# Altium schematic sheet GSMRelay.SchDoc(GSMRelay)
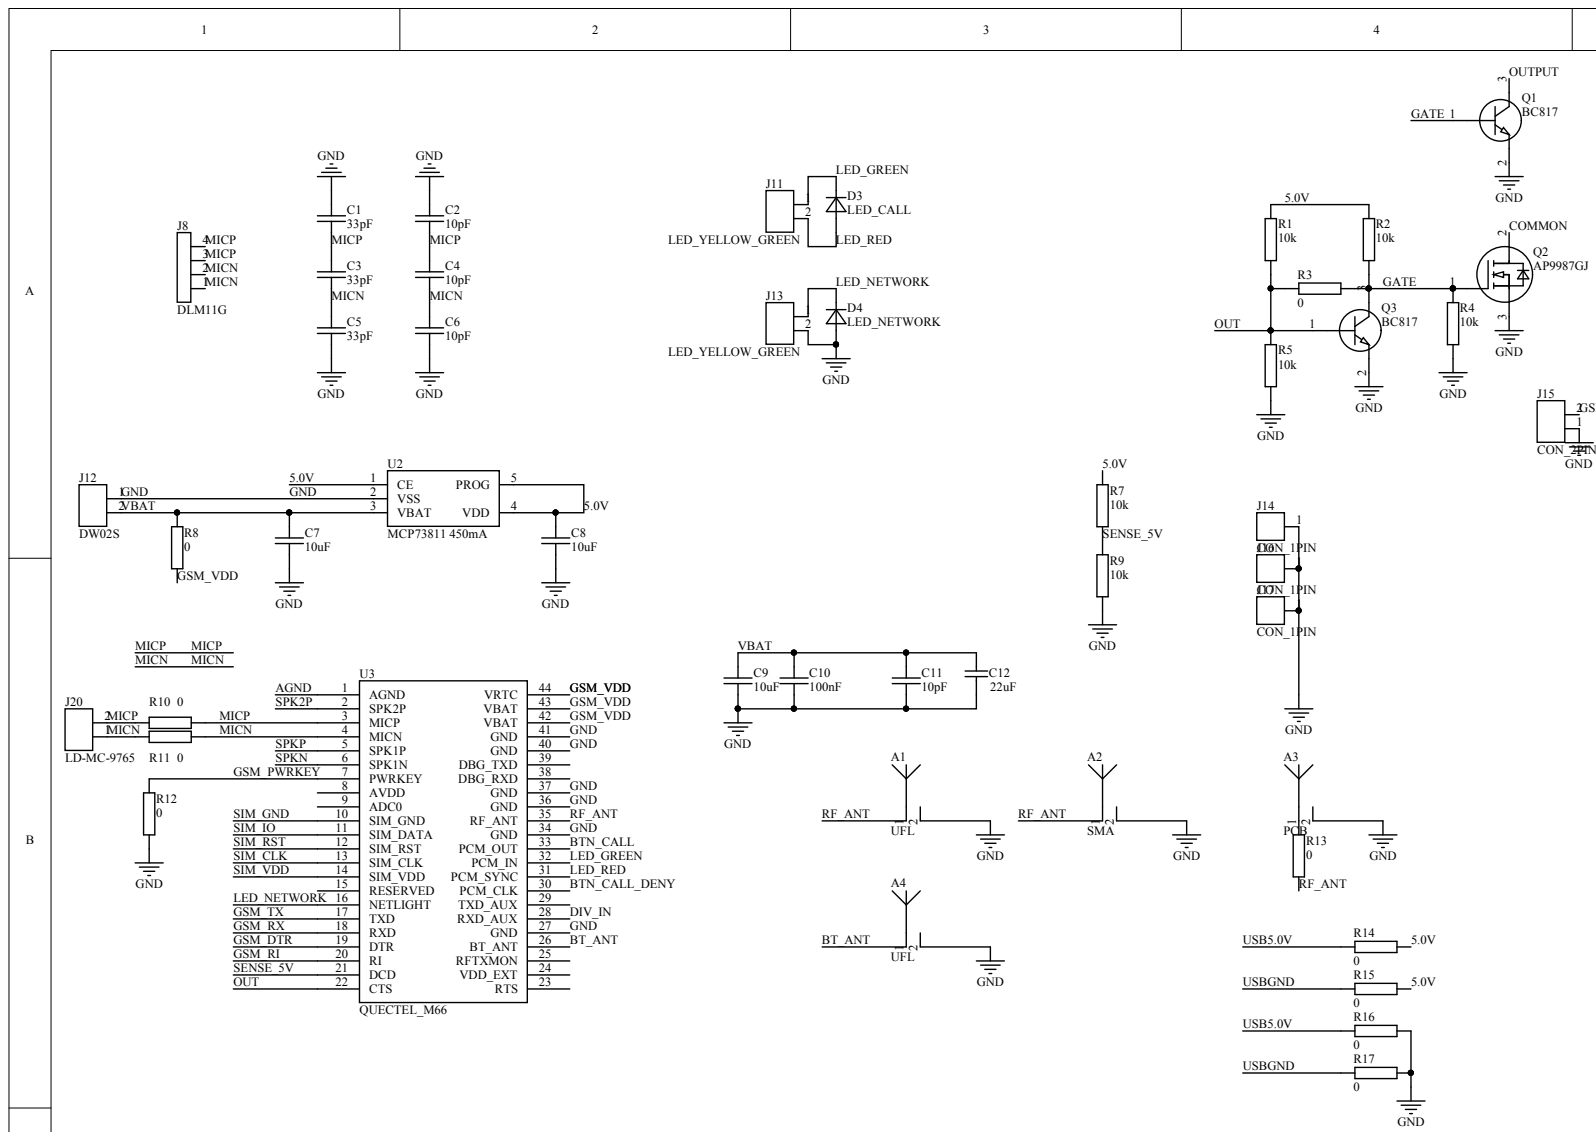
[diagram p0: GSMRelay.SchDoc, top left]
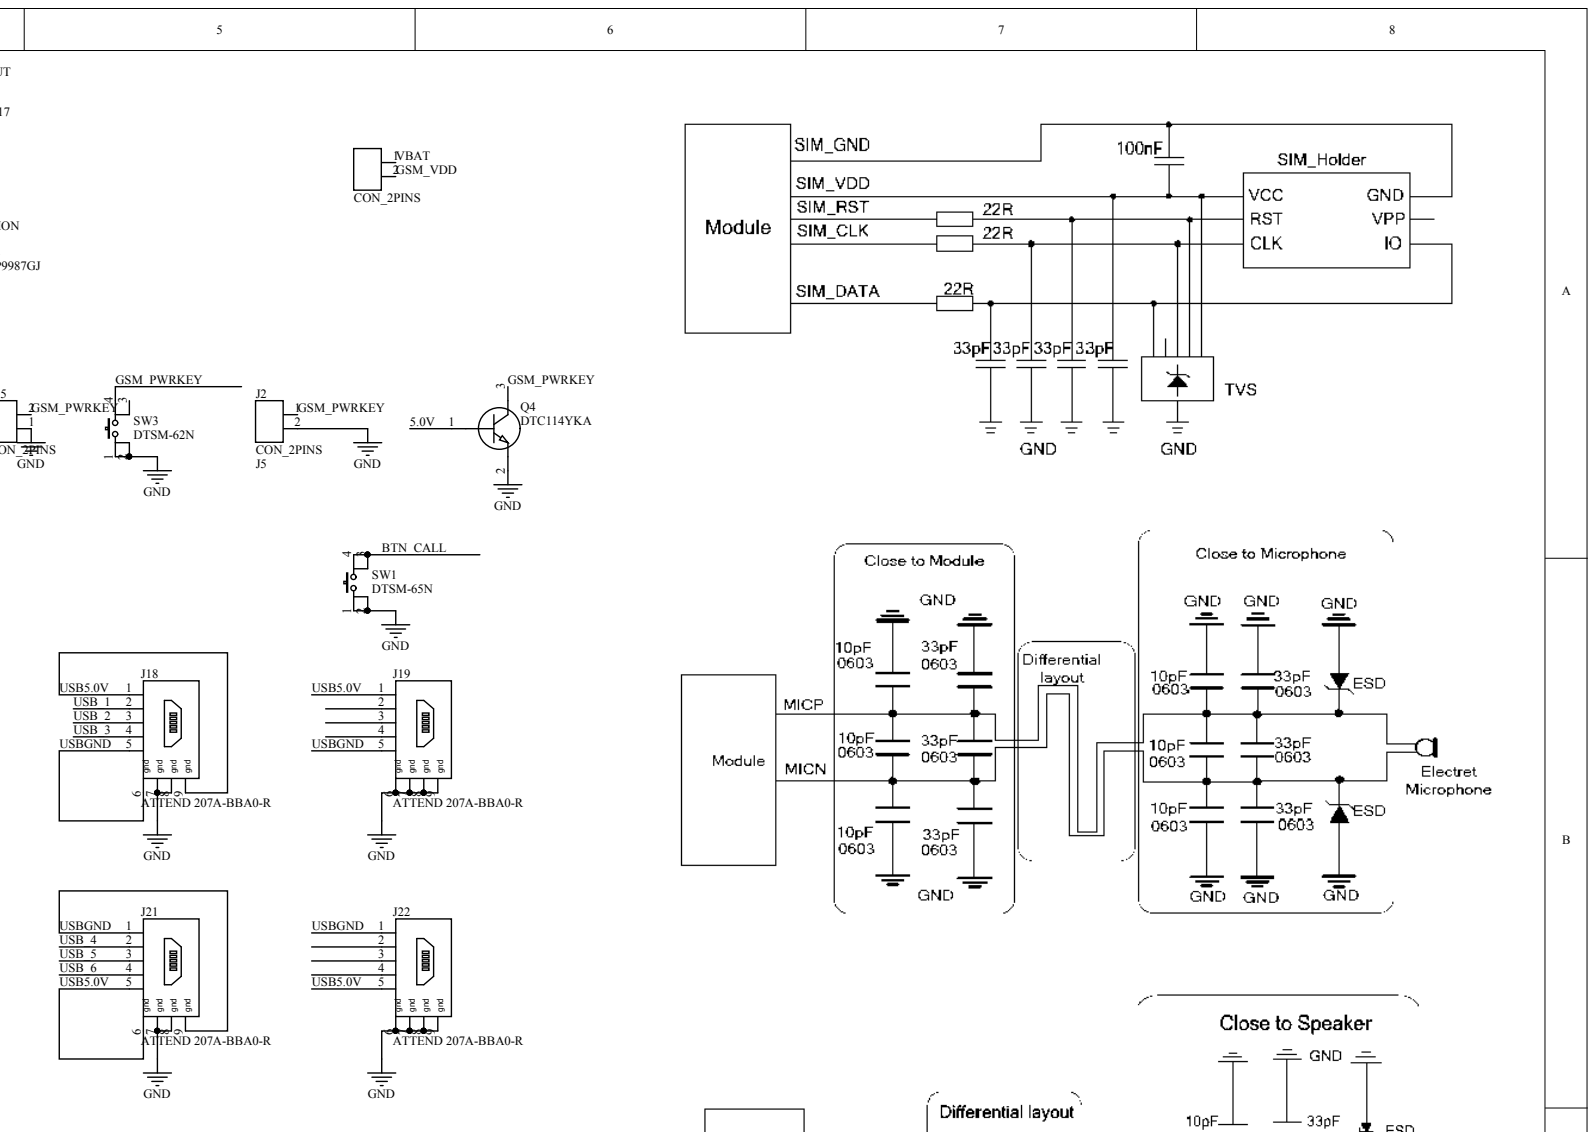
[diagram p1: GSMRelay.SchDoc, top right]
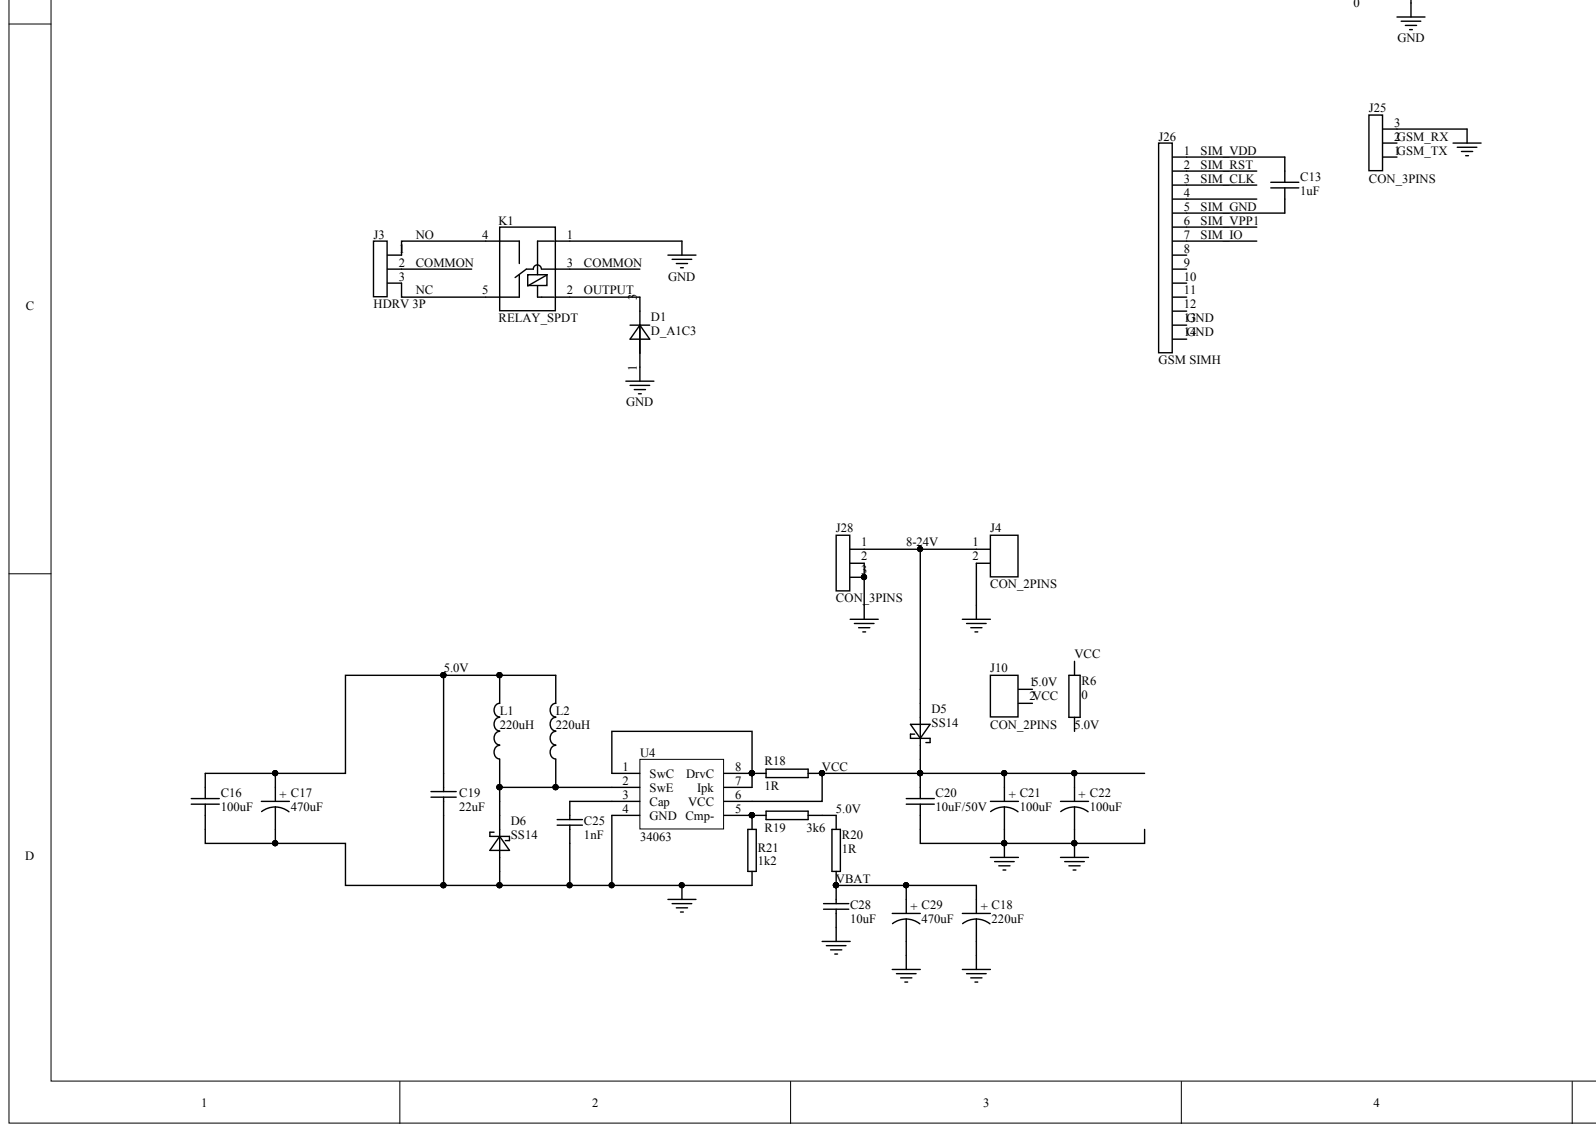
[diagram p2: GSMRelay.SchDoc, bottom left]
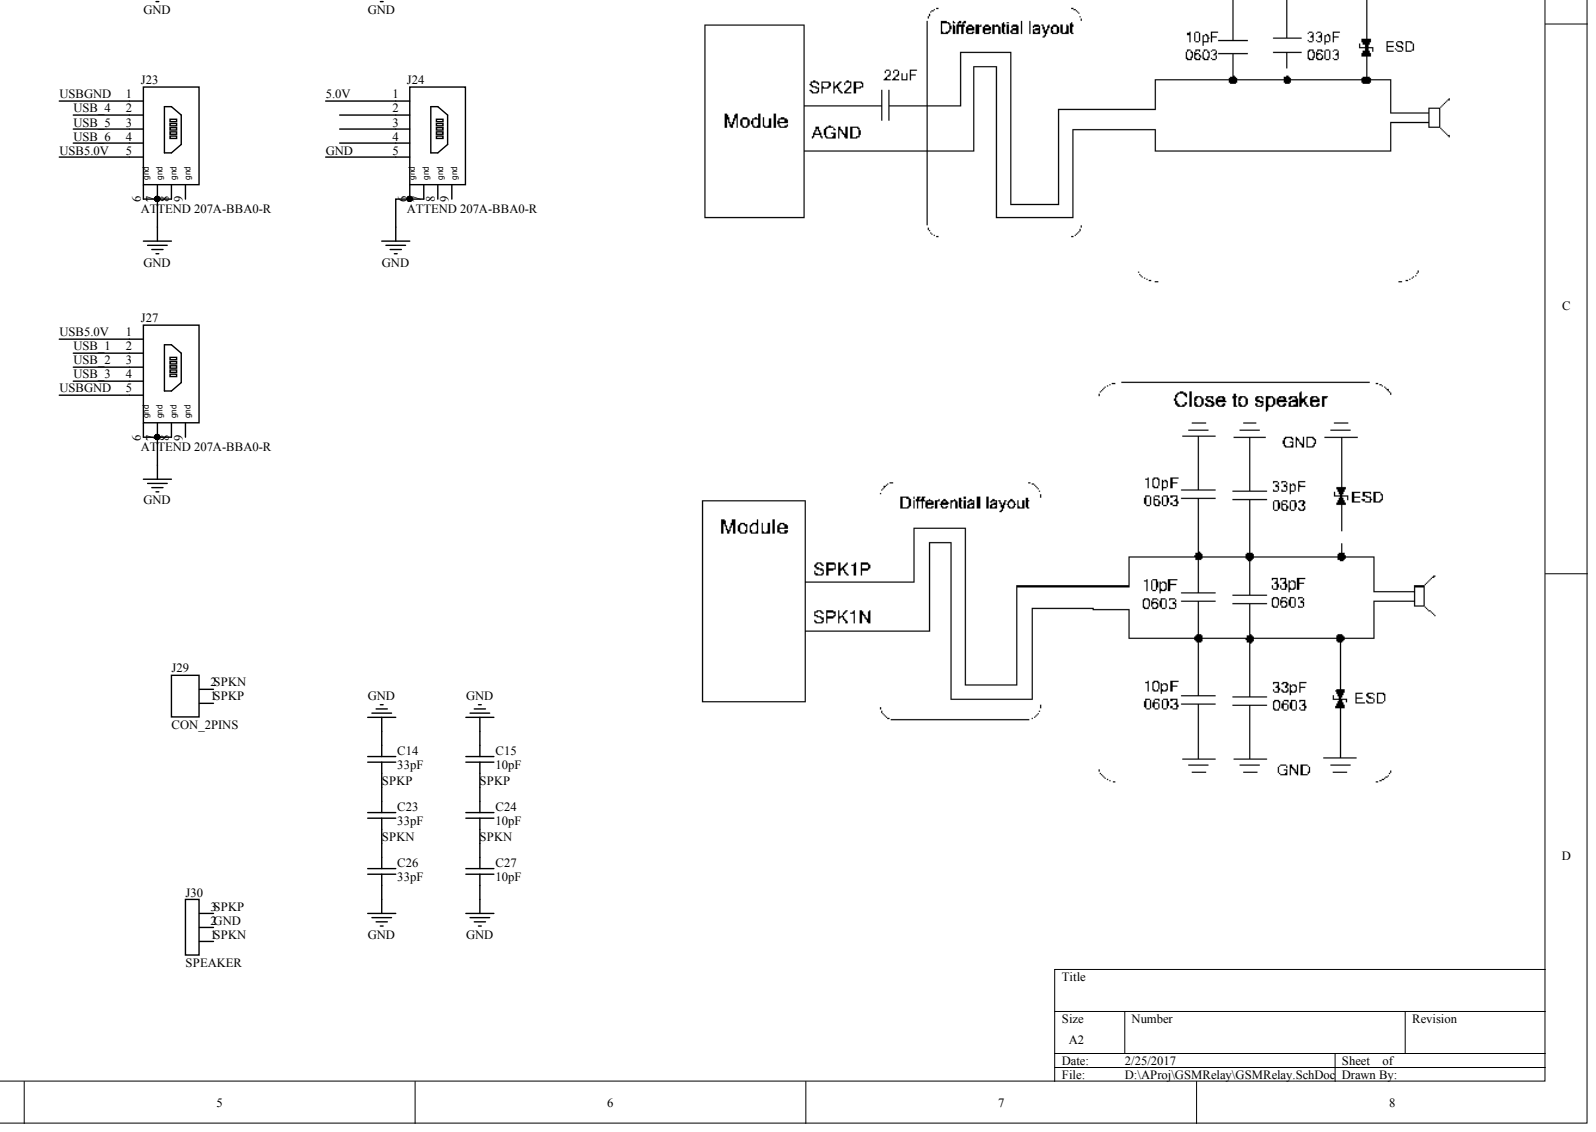
[diagram p3: GSMRelay.SchDoc, bottom right]

## Parts
A1  UFL  [SMD_CON_ANTENNA_UFL]
A2  SMA  [SMD_CON_ANTENNA_SMA_RIGHT_ANGLE]
A3  PCB  [SMD_CON_ANTENNA_GSM_PCB_1]
A4  UFL  [SMD_CON_ANTENNA_UFL]
C1  33pF  [SMD_0603_CAPC1608X95N]
C2  10pF  [SMD_0603_CAPC1608X95N]
C3  33pF  [SMD_0603_CAPC1608X95N]
C4  10pF  [SMD_0603_CAPC1608X95N]
C5  33pF  [SMD_0603_CAPC1608X95N]
C6  10pF  [SMD_0603_CAPC1608X95N]
C7  10uF  [SMD_0603_CAPC1608X95N]
C8  10uF  [SMD_0603_CAPC1608X95N]
C9  10uF  [SMD_0805_CAPC2012X95N]
C10  100nF  [SMD_0603_CAPC1608X95N]
C11  10pF  [SMD_0603_CAPC1608X95N]
C12  22uF  [SMD_1210_CAPC3225X279N]
C13  1uF  [SMD_0603_CAPC1608X95N]
C14  33pF  [SMD_0603_CAPC1608X95N]
C15  10pF  [SMD_0603_CAPC1608X95N]
C16  100uF  [SMD_1210_CAPC3225X279N]
C17  470uF  [PTH_CAPPR250-800X800]
C18  220uF  [SMD_CAPAE660X550N]
C19  22uF  [SMD_0805_CAPC2012X145N]
C20  10uF/50V  [SMD_1210_CAPC3225X279N]
C21  100uF  [SMD_CAPAE660X550N]
C22  100uF  [PTH_CAPPR250-800X800]
C23  33pF  [SMD_0603_CAPC1608X95N]
C24  10pF  [SMD_0603_CAPC1608X95N]
C25  1nF  [SMD_0603_CAPC1608X95N]
C26  33pF  [SMD_0603_CAPC1608X95N]
C27  10pF  [SMD_0603_CAPC1608X95N]
C28  10uF  [SMD_0603_CAPC1608X95N]
C29  470uF  [PTH_CAPPR250-800X800]
D1  D_A1C3  [SMD_SOT23_SOT95P240X120-3N]
D3  LED_CALL  [SMD_0805_LED2012X100N]
D4  LED_NETWORK  [SMD_0805_LED2012X100N]
D5  SS14  [SMD_DO214AC_SMA]
D6  SS14  [SMD_DO214AC_SMA]
J2  CON_2PINS  [PTH_HDRV02W64P254_1X02]
J3  HDRV 3P  [PTH_HDRV03W11060P500_1X03]
J4  CON_2PINS  [PTH_HDRV02W11060P350_1X02]
J5  CON_2PINS  [PTH_HDRV02W64P254_1X02]
J8  DLM11G  [NA]
J10  CON_2PINS  [PTH_HDRV02W64P254_1X02]
J11  LED_YELLOW_GREEN  [PTH_LED-KINGBRIGHT-L-934SGC]
J12  DW02S  [PTH_HDRV02W64P254_1X02_BATTERY]
J13  LED_YELLOW_GREEN  [PTH_LED-KINGBRIGHT-L-934SGC]
J14  CON_1PIN  [PTH_MOUNT_HOLE]
J15  CON_2PINS  [PTH_HDRV02W64P254_1X02]
J16  CON_1PIN  [PTH_MOUNT_HOLE]
J17  CON_1PIN  [PTH_MOUNT_HOLE]
J18  ATTEND 207A-BBA0-R  [SMD_CON_MICRO_USB]
J19  ATTEND 207A-BBA0-R  [SMD_CON-CVILUX-CU04-SCM15B0]
J20  LD-MC-9765  [PTH_MIC_9.6MM_6MM]
J21  ATTEND 207A-BBA0-R  [SMD_CON_MICRO_USB]
J22  ATTEND 207A-BBA0-R  [SMD_CON-CVILUX-CU04-SCM15B0]
J23  ATTEND 207A-BBA0-R  [SMD_CON_MICRO_USB_STRAIGHT]
J24  ATTEND 207A-BBA0-R  [NA]
J25  CON_3PINS  [PTH_HDRV03W64P254_1X03]
J26  GSM SIMH  [SMD_CON-ATTEND_SIM_115B-AAA0-R01]
J27  ATTEND 207A-BBA0-R  [SMD_CON_MICRO_USB_STRAIGHT]
J28  CON_3PINS  [PTH_DC-POWER-JACK_210-112]
J29  CON_2PINS  [PTH_HDRV02W64P254_1X02]
J30  SPEAKER  [PTH_SPEAKER_MCABS-227-RC]
K1  RELAY_SPDT  [PTH_REL_RAS]
L1  220uH  [SMD_INDM600500]
L2  220uH  [PTH_CAPPR250-800X800]
Q1  BC817  [SMD_SOT23_SOT95P240X120-3N]
Q2  AP9987GJ  [PTH_TO220AB]
Q3  BC817  [SMD_SOT23_SOT95P240X120-3N]
Q4  DTC114YKA  [SMD_SOT23_SOT95P240X120-3N]
R1  10k  [SMD_0603_RESC1608X55N]
R2  10k  [SMD_0603_RESC1608X55N]
R3  0  [SMD_0603_RESC1608X55N]
R4  10k  [SMD_0603_RESC1608X55N]
R5  10k  [SMD_0603_RESC1608X55N]
R6  0  [SMD_0603_RESC1608X55N]
R7  10k  [SMD_0603_RESC1608X55N]
R8  0  [SMD_0603_RESC1608X55N]
R9  10k  [SMD_0603_RESC1608X55N]
R10  0  [NA]
R11  0  [NA]
R12  0  [SMD_0603_RESC1608X55N]
R13  0  [SMD_0402_RESC1005X37N]
R14  0  [SMD_0603_RESC1608X55N]
R15  0  [SMD_0603_RESC1608X55N]
R16  0  [SMD_0603_RESC1608X55N]
R17  0  [SMD_0603_RESC1608X55N]
R18  1R  [SMD_0805_RESC2012X60N]
R19  3k6  [SMD_0603_RESC1608X55N]
R20  1R  [SMD_0603_RESC1608X55N]
R21  1k2  [SMD_0603_RESC1608X55N]
SW1  DTSM-65N  [SMD_SW_TACT-64K_5]
SW3  DTSM-62N  [SMD_SW_TACT-64K_5]
U2  MCP73811 450mA  [SMD_SOT26_SOT95P285X140-5N - DLV]
U3  QUECTEL_M66  [SMD_MOD_M66-44N]
U4  34063  [SMD_SOIC127P600X175-8N]

## Nets
5.0V: C8.1 C16.2 C17.1 C19.1 J10.1 J24.1 L1.2 L2.2 Q4.1 R1.1 R2.1 R6.2 R7.1 R14.1 R15.1 R19.1 R20.2 U2.1(CE) U2.4(VDD) U2.5(PROG)
8-24V: D5.2 J4.1 J28.1
AGND: U3.1(AGND)
BT_ANT: A4.1 U3.26(BT_ANT)
BTN_CALL: SW1.3 SW1.4 U3.33(PCM_OUT)
BTN_CALL_DENY: U3.30(PCM_CLK)
COMMON: J3.2 K1.3 Q2.2
DIV_IN: U3.28(RXD_AUX)
GATE: Q1.1 Q2.1 Q3.3 R2.2 R3.2 R4.1
GND: A1.2 A2.2 A3.2 A4.2 C1.1 C2.1 C5.2 C6.2 C7.2 C8.2 C9.2 C10.2 C11.2 C12.2 C14.1 C15.1 C16.1 C17.2 C18.2 C19.2 C20.1 C21.2 C22.2 C25.2 C26.2 C27.2 C28.1 C29.2 D1.1 D4.2 D6.2 J2.2 J4.2 J12.1 J13.2 J14.1 J15.1 J16.1 J17.1 J18.7 J18.8 J19.6(gnd) J19.7 J19.8 J19.9(gnd) J21.7 J21.8 J22.6(gnd) J22.7 J22.8 J22.9(gnd) J23.6 J23.7 J23.8 J24.5 J24.6 J24.7 J25.3 J26.13 J26.14 J27.6 J27.7 J27.8 J28.2 J28.3 J30.2 K1.1 Q1.2 Q2.3 Q3.2 Q4.2 R4.2 R5.2 R9.2 R12.2 R16.1 R17.1 R21.2 SW1.1 SW1.2 SW3.1 SW3.2 U2.2(VSS) U3.27(GND) U3.34(GND) U3.36(GND) U3.37(GND) U3.40(GND) U3.41(GND) U4.4(GND)
GSM_DTR: U3.19(DTR)
GSM_PWRKEY: J2.1 J15.2 Q4.3 R12.1 SW3.4 U3.7(PWRKEY)
GSM_RI: U3.20(RI)
GSM_RX: J25.2 U3.18(RXD)
GSM_TX: J25.1 U3.17(TXD)
GSM_VDD: J5.2 R8.1 U3.42(VBAT) U3.43(VBAT) U3.44(VRTC)
LED_GREEN: D3.1 J11.1 U3.32(PCM_IN)
LED_NETWORK: D4.1 J13.1 U3.16(NETLIGHT)
LED_RED: D3.2 J11.2 U3.31(PCM_SYNC)
MICN: C3.2 C4.2 C5.1 C6.1 J8.1 J8.2 J20.1 R11.1 R11.2 U3.4(MICN)
MICP: C1.2 C2.2 C3.1 C4.1 J8.3 J8.4 J20.2 R10.1 R10.2 U3.3(MICP)
NC: J3.3 K1.5
NO: J3.1 K1.4
OUT: Q3.1 R1.2 R3.1 R5.1 U3.22(CTS)
OUTPUT: D1.3 K1.2 Q1.3
RF_ANT: A1.1 A2.1 R13.2 U3.35(RF_ANT)
SENSE_5V: R7.2 R9.1 U3.21(DCD)
SIM_CLK: J26.3 U3.13(SIM_CLK)
SIM_GND: C13.2 J26.5 U3.10(SIM_GND)
SIM_IO: J26.7 U3.11(SIM_DATA)
SIM_RST: J26.2 U3.12(SIM_RST)
SIM_VDD: C13.1 J26.1 U3.14(SIM_VDD)
SIM_VPP1: J26.6
SPK2P: U3.2(SPK2P)
SPKN: C23.2 C24.2 C26.1 C27.1 J29.2 J30.1 U3.6(SPK1N)
SPKP: C14.2 C15.2 C23.1 C24.1 J29.1 J30.3 U3.5(SPK1P)
USB5.0V: J18.1 J18.9 J19.1 J21.5 J21.6 J22.5 J23.5 J27.1 R14.2 R16.2
USB_1: J18.2 J27.2
USB_2: J18.3 J27.3
USB_3: J18.4 J27.4
USB_4: J21.2 J23.2
USB_5: J21.3 J23.3
USB_6: J21.4 J23.4
USBGND: J18.5 J18.6 J19.5 J21.1 J21.9 J22.1 J23.1 J27.5 R15.2 R17.2
VBAT: C7.1 C9.1 C10.1 C11.1 C12.1 C18.1 C28.2 C29.1 J5.1 J12.2 R8.2 R20.1 U2.3(VBAT)
VCC: C20.2 C21.1 C22.1 D5.1 J10.2 R6.1 R18.2 U4.6(VCC)
NetA3_1: A3.1 R13.1
NetC25_1: C25.1 U4.3(Cap)
NetD6_1: D6.1 L1.1 L2.1 U4.2(SwE)
NetR18_1: R18.1 U4.1(SwC) U4.7(Ipk) U4.8(DrvC)
NetR19_2: R19.2 R21.1 U4.5(Cmp-)

Not connected: J19 2 3 4; J22 2 3 4; J23 9; J24 2 3 4 8(gnd) 9; J26 4 8 9 10 11 12; J27 9; SW3 3; U3 8(AVDD) 9(ADC0) 15(RESERVED) 23(RTS) 24(VDD_EXT) 25(RFTXMON) 29(TXD_AUX) 38(DBG_RXD) 39(DBG_TXD)

## Library data of the parts
A1-A4 = ANTENNA_2: NOTE: <NOTE>; Component Kind: Standard; Library Name: DLV.SchLib
C1-C11 C13-C16 C20 C23 C24 C26 C27 = C: NOTE: <NOTE>; Component Kind: Standard; Library Name: DLV.SchLib
C12 = Cap Semi: CL32A227MQVNNNE 220UF 6.3V 20% X5R 1210; Component Kind: Standard; LatestRevisionDate: 29-May-2009; LatestRevisionNote: IPC-7351 Footprint Added.; Library Name: Miscellaneous Devices.IntLib; PackageDescription: Chip Capacitor; PackageReference: C1206; Published: 8-Jun-2000; Signal Integrity: Cap Semi; Simulation: CAP; Value: 22uF; Publisher: Altium Limited
C17 C18 C21 C22 C29 = CAPACITOR POL: Capacitor; Component Kind: Standard
C19 C25 C28 = CAP: Capacitor; Component Kind: Standard
D1 = D_A1C3: 1N4148; NOTE: <NOTE>; Component Kind: Standard; Library Name: DLV.SchLib
D3 D4 = D: NOTE: <NOTE>; Component Kind: Standard; Library Name: DLV.SchLib
D5 D6 = D_STKY: NOTE: <NOTE>; Component Kind: Standard; Library Name: BitCoin.SchLib
J2 J4 J5 J10-J13 J15 J20 J29 = CON_2PINS: NOTE: <NOTE>; Component Kind: Standard; Library Name: DLV.SchLib
J3 J30 = CON_3PINS: Component Kind: Standard; Library Name: DLV.SchLib
J8 = CON_4PINS: Component Kind: Standard; Library Name: DLV.SchLib
J14 J16 J17 = CON_1PIN: NOTE: <NOTE>; Component Kind: Standard; Library Name: DLV.SchLib
J18 J19 J21-J24 J27 = CON_USB_MINI: NOTE: <NOTE>; Component Kind: Standard; Library Name: DLV.SchLib
J26 = CON_14PINS: Component Kind: Standard; Library Name: DLV.SchLib
L1 = INDUCTOR1: FPI0504 DA54; Component Kind: Standard; Library Name: Miscellaneous Devices.lib
L2 = INDUCTOR1: Component Kind: Standard; Library Name: Miscellaneous Devices.lib
Q1 Q3 Q4 = BJT_NPN_B1E2C3: NOTE: <NOTE>; Component Kind: Standard; Library Name: DLV.SchLib
Q2 = MOS_N_D1_G1D2S3: NOTE: <NOTE>; Component Kind: Standard; Library Name: DLV.SchLib
R1-R17 = R: NOTE: <NOTE>; Component Kind: Standard; R_BDS: R; Library Name: DLV.SchLib
R18-R21 = RES2: Component Kind: Standard; Library Name: Miscellaneous Devices.lib
SW1 SW3 = BUTTON_1: Component Kind: Standard; Library Name: DLV.SchLib
U2 = (no symbol name): MCP73812; DB_MANUFACTURER_PART: ROHM        BD33KA5WF-E2; DB_PART_NUMBER: PSU000000127; DB_PART_TYPE: Linear Voltage Regulator; DB_APPROVED_BY: VSN; DB_APPROVED_ON: 07.5.2014 г. 13:18:28; DB_CERTIFIED: ISHIDDEN=T; DB_CURRENT: 500mA; DB_DESCRIPTION: IC BD33KA5WF-E2 SOIC-8; DB_PACKAGE: SOIC-8; DB_PCB_DEVICE: SMD_SOIC127P620X160-8N; DB_STATUS: NEW; DB_T_RANGE: -40 to 105; DB_VOLTAGE: 3.3V; Component Kind: Standard; DB_DATASHEET: http://john.epiqdesign.com/projects/CIS_Datasheets/IC_bdxxka5wf-e-209388.pdf?rev=1408&sc=0; Library Name: DLV.SchLib
U3 = MOD_QUECTEL_M66: Component Kind: Standard; Library Name: DLV.SchLib
U4 = 34063: DC/DC converter; Component Kind: Standard; Library Name: Devices.Lib
J25 J28 = CON_3PINS: Component Kind: Standard; Library Name: DLV.SchLib
K1 = RELAY_SPDT: Component Kind: Standard; Library Name: DLV.SchLib
